# Altium schematic sheet PAGE02_机场端电源.SchDoc
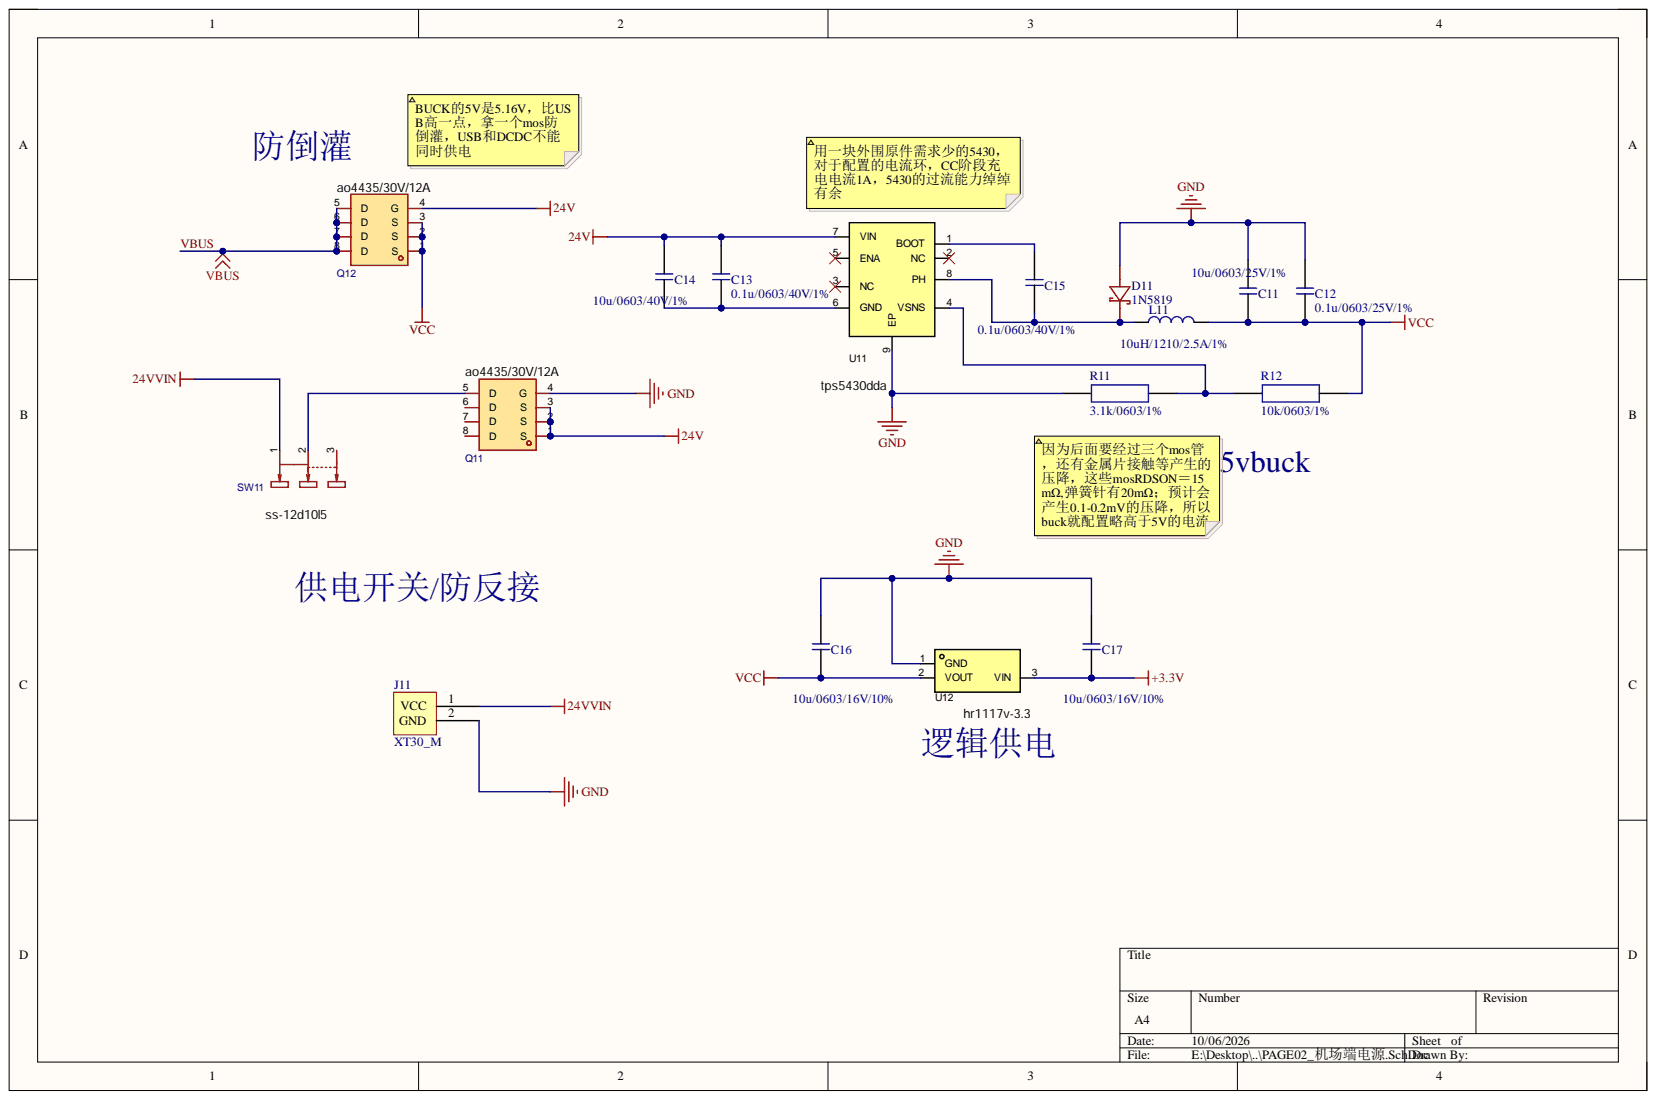
[diagram p0: PAGE02_机场端电源.SchDoc]

## Parts
C11  10u/0603/25V/1%  [0603_ding_C]
C12  0.1u/0603/25V/1%  [0603_ding_C]
C13  0.1u/0603/40V/1%  [0603_ding_C]
C14  10u/0603/40V/1%  [0603_ding_C]
C15  0.1u/0603/40V/1%  [0603_ding_C]
C16  10u/0603/16V/10%  [0603_ding_C]
C17  10u/0603/16V/10%  [0603_ding_C]
D11  1N5819  [SOD-123_L2.7-W1.6-LS3.7-RD]
J11  XT30_M  [XT30（立式）]
L11  10uH/1210/2.5A/1%  [1210_ding_C]
Q11  ao4435/30V/12A  [SOP-8_L5.0-W3.8-P1.27-LS6.0-BL]
Q12  ao4435/30V/12A  [SOP-8_L5.0-W3.8-P1.27-LS6.0-BL]
R11  3.1k/0603/1%  [0603_ding_R]
R12  10k/0603/1%  [0603_ding_R]
SW11  ss-12d10l5  [SW-TH_SS-12D10L5]
U11  tps5430dda  [ESOP-8_L4.9-W3.9-P1.27-LS6.0-BL-EP-2]
U12  hr1117v-3.3  [SOT-89-3_L4.5-W2.4-P1.50-LS4.0-BR]

## Nets
24V: C13.2 C14.2 Q11.1(S) Q11.2(S) Q11.3(S) Q12.4(G) U11.7(VIN)
24VVIN: J11.1 SW11.1
+3.3V: C17.1 U12.3
GND: C11.2 C12.2 C16.2 C17.2 D11.2 J11.2 Q11.4(G) R11.2 U11.9(EP) U12.1
VCC: C11.1 C12.1 C16.1 L11.2 Q12.1(S) Q12.2(S) Q12.3(S) R12.1 U12.2
VBUS: Q12.5(D) Q12.6(D) Q12.7(D) Q12.8(D)
NetC13_1: C13.1 C14.1 U11.6(GND)
NetC15_1: C15.1 U11.1(BOOT)
NetC15_2: C15.2 D11.1 L11.1 U11.8(PH)
NetQ11_5: Q11.5(D) SW11.2
NetR11_1: R11.1 R12.2 U11.4(VSNS)

Not connected: Q11 6(D) 7(D) 8(D); SW11 3; U11 2(NC) 3(NC) 5(ENA)

## Library data of the parts
C11-C17 = C: Component Kind: Standard; Library Name: IP6826.SchLib
L11 = L-ip6826: Component Kind: Standard; Library Name: IP6826.SchLib
Q11 Q12 = ao4435: 数量:1个P沟道;漏源电压(Vdss):30V;连续漏极电流(Id):12A;导通电阻(RDS(on)):15mΩ@10V;耗散功率(Pd):2.5W;阈值电压(Vgs(th)):3V;; Manufacturer: UMW(友台半导体); AddInBom: yes; Current - Continuous Drain(Id): 12A; Drain to Source Voltage: 30V; Gate Charge(Qg): 18nC; Gate Threshold Voltage (Vgs(th)): 3V; Input Capacitance(Ciss): 1.175nF; ModelId: 823e4ad1ee284f09bfed9f55dae295e0; ModelTransform: 196.85,236.22,0,0,0,0,0,0,0; Number: 1个P沟道; Output Capacitance(Coss): 195pF; Pd - Power Dissipation: 2.5W; Reverse Transfer Capacitance (Crss@Vds): 190pF; Symbol: AO4435_C2944310; Component Kind: Standard; ConvertToPcb: yes; Datasheet: https://item.szlcsc.com/datasheet/AO4435/3330325.html; FootprintName: SOP-8_L5.0-W3.8-P1.27-LS6.0-BL; JLCPCB Part Class: Extended Part; LCSC Part Name: 1个P沟道 耐压:30V 电流:12A; Library Name: AO4435_C2944310.SCHLIB; ManufacturerPart: AO4435; ModelName: SOP-8_L5.0-W3.8-P1.27-LS6.0-BL; Operating Temperature: -55℃~+150℃; RDS(on): 15mΩ@10V; Supplier: LCSC; Supplier Footprint: SOP-8; SupplierPart: C2944310
R11 R12 = RES: Component Kind: Standard; Library Name: IP6826.SchLib
SW11 = ss-12d10l5: 电路结构:单刀双掷;安装方式:直插;开关颜色:黑色;额定电流:3A;额定电压:125V;工作寿命:1万次;; Manufacturer: XKB Connection(中国星坤); Actuator Color: 黑色; Actuator Style: 矩形柱状; AddInBom: yes; Circuit: 单刀双掷; Current Rating: 3A; Life: 1万次; ModelId: c49f07a32c154ec8ad7cf47670a53981; ModelName: SW-TH_L13.0-W6.8-H6.4-P4.7; ModelTransform: 511.81394,267.716,0,0,0,0,0,0,-220.472; Switch Height: 6.4mm; Voltage Rating: 125V; Component Kind: Standard; ConvertToPcb: yes; Datasheet: https://item.szlcsc.com/datasheet/SS-12D10L5/300104.html; FootprintName: SW-TH_SS-12D10L5; JLCPCB Part Class: Extended Part; LCSC Part Name: 柄长5mm 直插双档 滑动开关; Length: 13mm; Library Name: SS-12D10L5.SCHLIB; ManufacturerPart: SS-12D10L5; Mounting Type: 直插; Operating Temperature: -40℃~+85℃; Supplier: LCSC; Supplier Footprint: 插件,13x6.8mm; SupplierPart: C319012; Symbol: SS-12D10L5; Termination Style: PC引脚; Width: 6.8mm
U11 = tps5430dda: 功能类型:降压型;工作电压:5.5V~36V;输出电压:1.221V~32.04V;输出电流:3A;输出类型:可调;; Manufacturer: TI(德州仪器); AddInBom: yes; Frequency - Switching: 500kHz; Function: 降压型; ModelId: 0078439d9e964752ad4b3f828dcb5664; ModelTransform: 192.913,236.22,0,0,0,0,0,0,0; Number of Outputs: 1; Output Current: 3A; Output Type: 可调; Quiescent Current: 4.4mA; Switch tube (built-in/external): 内置; Synchronous Rectifier: 否; Topology: 降压式; Component Kind: Standard; ConvertToPcb: yes; Datasheet: https://www.ti.com/cn/lit/ds/symlink/tps5430.pdf; Features: 逐波限流；过流保护；打嗝限流; FootprintName: ESOP-8_L4.9-W3.9-P1.27-LS6.0-BL-EP-2; JLCPCB Part Class: Extended Part; LCSC Part Name: 降压型 3A 5.5V~36V; Library Name: TPS5430DDA.SCHLIB; ManufacturerPart: TPS5430DDA; ModelName: ESOP-8_L4.9-W3.9-P1.27-LS6.0-BL-EP-2; Operating Temperature: -40℃~+125℃@(TJ); Output Voltage: 1.221V~32.04V; Supplier: LCSC; Supplier Footprint: ESOP-8; SupplierPart: C502477; Symbol: TPS5430DDA; Voltage - Supply: 5.5V~36V
U12 = hr1117v-3.3: 输出极性:正极;工作电压:15V;输出电压:3.3V;输出电流:1A;电源纹波抑制比(PSRR):-;输出类型:固定;; Manufacturer: R+O(宏嘉诚); AddInBom: yes; ModelId: dde435042bae4b9691e74da94cf9d9f3; ModelTransform: 177.165,161.417,0,90,0,0,-2.323,0,0; Noise: 0.003%Vout; Number of Outputs: 1; Output Configuration: 正极; Output Current: 1A; Output Type: 固定; Output Voltage: 3.3V; standby current: 2mA; Voltage - Supply: 15V; Voltage Dropout: 1.1V@(1A); Component Kind: Standard; ConvertToPcb: yes; Datasheet: https://item.szlcsc.com/datasheet/HR1117V-3.3/57930308.html; Features: 热关断; FootprintName: SOT-89-3_L4.5-W2.4-P1.50-LS4.0-BR; JLCPCB Part Class: Extended Part; LCSC Part Name: 低压差线性稳压器，分固定电压输出和可调电压输出版本; Library Name: HR1117V-3.3.SCHLIB; ManufacturerPart: HR1117V-3.3; ModelName: SOT-89-3_L4.5-W2.4-P1.50-LS4.0-BR; Operating Temperature: -40℃~+85℃; Power Supply Rejection Ratio (PSRR): -; Supplier: LCSC; Supplier Footprint: SOT-89; SupplierPart: C54560864; Symbol: HR1117V-3.3
D11 = 1N5819: Component Kind: Standard; Library Name: IP6826.SchLib
J11 = XT30_M: Component Kind: Standard; Library Name: RobotLab_Common_Used.SchLib
